# Altium schematic sheet Capteurs-projet-P1.SchDoc(Capteurs-projet-P1)
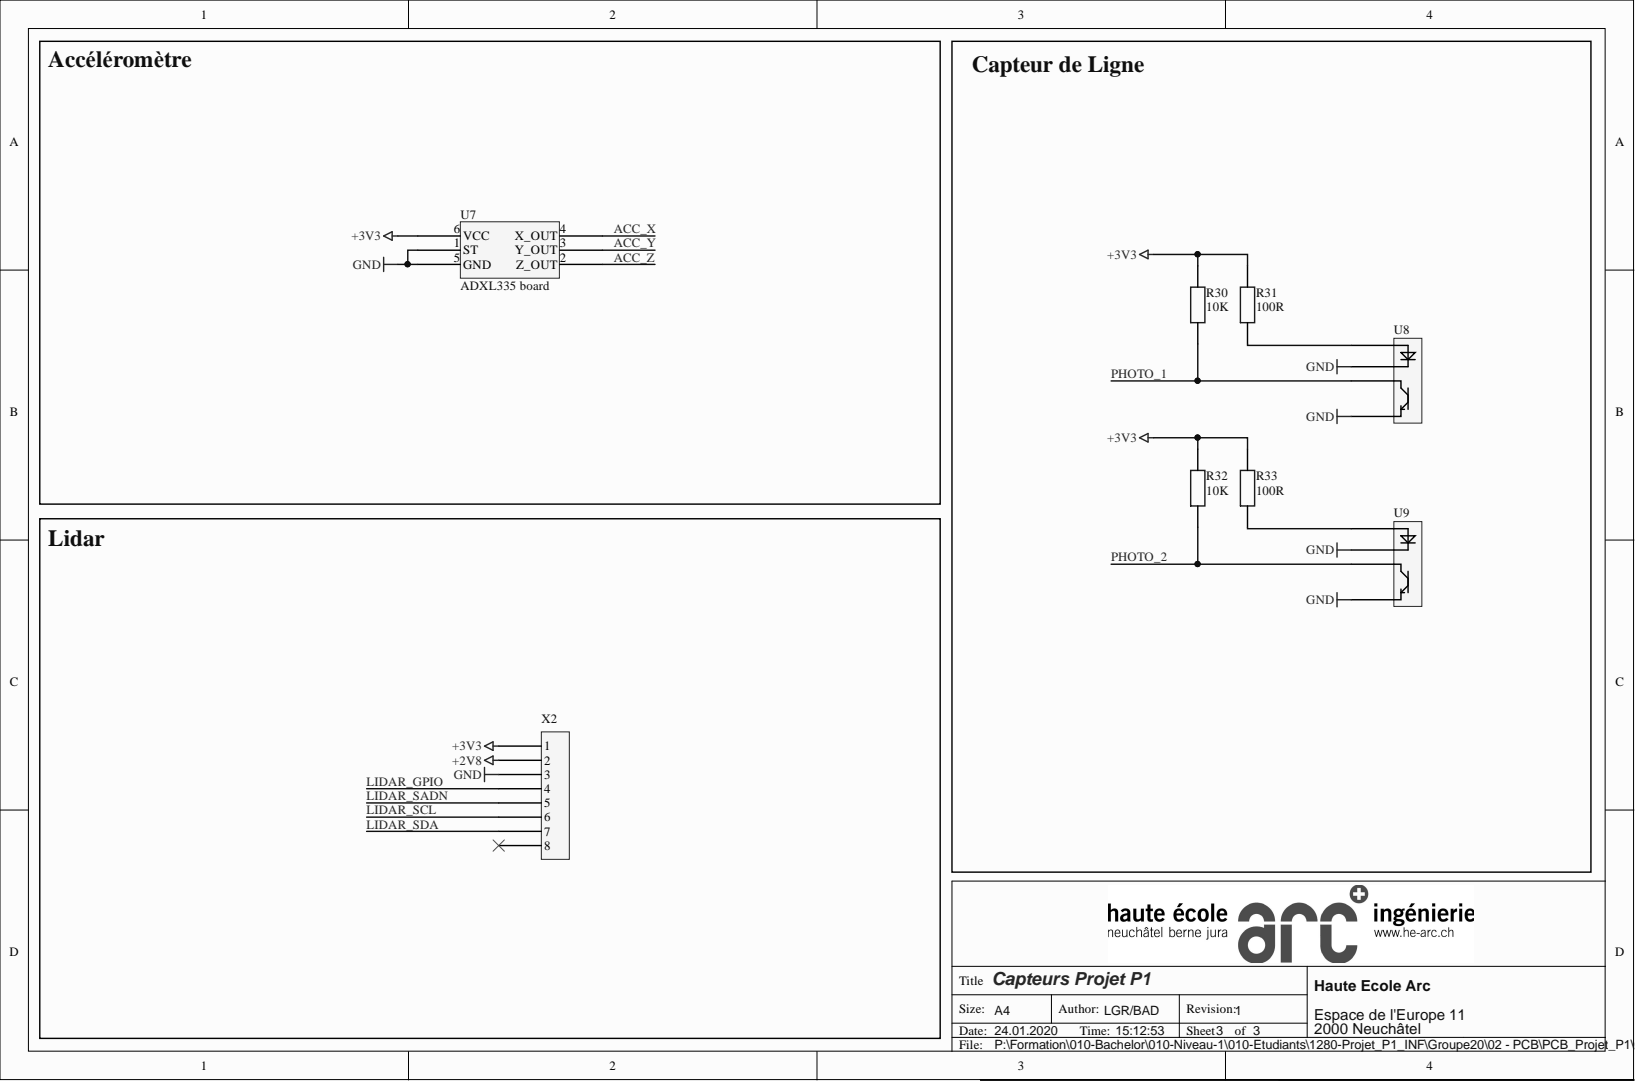
[diagram p0: Capteurs-projet-P1.SchDoc]

## Parts
R30  10K  [080501]
R31  100R  [080501]
R32  10K  [080501]
R33  100R  [080501]
U7  ADXL335 board  [SEN-09269]
U8  ITR20001/T  [ITR20001/T]  (value not drawn)
U9  ITR20001/T  [ITR20001/T]  (value not drawn)
X2  MJ1-2503A  [61200821721]  (value not drawn)

## Nets
+2V8: X2.2
+3V3: R30.2 R31.2 R32.2 R33.2 U7.6(VCC) X2.1
GND: U7.1(ST) U7.5(GND) U8.2 U8.3 U9.2 U9.3 X2.3
ACC_X: U7.4(X_OUT)
ACC_Y: U7.3(Y_OUT)
ACC_Z: U7.2(Z_OUT)
LIDAR_GPIO: X2.4
LIDAR_SADN: X2.5
LIDAR_SCL: X2.6
LIDAR_SDA: X2.7
PHOTO_1: R30.1 U8.4
PHOTO_2: R32.1 U9.4
NetR31_1: R31.1 U8.1
NetR33_1: R33.1 U9.1

Not connected: X2 8

## Library data of the parts
R30 R32 = Res-10K-0805: Résistance 10 K ohm 0805 0.125W; Ref. Fournisseur: 311-10.0KCRCT-ND; Ref. Fabricant: RC0805FR-0710KL; Prix 1 unit (CHF): 0.10; Fournisseur: Digikey; Fabricant: Yageo; Auteur: BAD; Library Name: Schlib-P1-G20.SchLib; ComponentLink1Description: datasheet; Component Kind: Standard; ComponentLink1URL: P:\Formation\010-Bachelor\010-Niveau-1\010-Etudiants\1280-Projet_P1_INF\Groupe20\01 - Documentation\01 - Composants electronique\11 - Composants passif\01 - Résistances\res-100K-0805.pdf
R31 R33 = Res-100R-0805: Résistance 100 R 0805; Ref. Fournisseur: RNCP0805FTD100RCT-ND; Ref. Fabricant: RNCP0805FTD100R; Prix 1 unit (CHF): 0.10; Fournisseur: Digikey; Fabricant: Stackpole Electronics Inc; Auteur: BAD; Library Name: Schlib-P1-G20.SchLib; ComponentLink1Description: datasheet; Component Kind: Standard; ComponentLink1URL: P:\Formation\010-Bachelor\010-Niveau-1\010-Etudiants\1280-Projet_P1_INF\Groupe20\01 - Documentation\01 - Composants electronique\11 - Composants passif\01 - Résistances\res-150-0805.pdf
U7 = ADXL335: Accéléromètre; Ref. Fournisseur: 1568-1044-ND; Prix 1 unit (CHF): 14.80; Fournisseur: Digikey; Fabricant: SparkFun; Auteur: BAD; Ref. Fabricant: SEN-09269; Library Name: Schlib-P1-G20.SchLib; ComponentLink1Description: datasheet; Component Kind: Standard; ComponentLink1URL: P:\Formation\010-Bachelor\010-Niveau-1\010-Etudiants\1280-Projet_P1_INF\Groupe20\01 - Documentation\01 - Composants electronique\02 - Accelerometre\ADXL335.pdf
U8 U9 = ITR20001/T: Capteur de ligne; Prix 1 unit (CHF): 0.83; Fournisseur: Distrelec; Fabricant: Everlight; Auteur: BAD; Ref. Fournisseur: 110-44-350; Ref. Fabricant: ITR20001/T; Library Name: Schlib-P1-G20.SchLib; ComponentLink1Description: datasheet; Component Kind: Standard; ComponentLink1URL: P:\Formation\010-Bachelor\010-Niveau-1\010-Etudiants\1280-Projet_P1_INF\Groupe20\01 - Documentation\01 - Composants electronique\04 - Capteur de position\ITR20001-T_eng_tds-2.pdf
X2 = 61200821721: Connecteur  Contre connecteur :  Digikey : 732-5444-ND; Ref. Fournisseur: 732-5405-ND; Prix 1 unit (CHF): 1.08; Fabricant: Wurth Electronics Inc.; Auteur: BAD; Ref. Fabricant: 61200821721; Library Name: Schlib-P1-G20.SchLib; Fournisseur: Digikey; ComponentLink1Description: datasheet; Component Kind: Standard; ComponentLink1URL: P:\Formation\010-Bachelor\010-Niveau-1\010-Etudiants\1280-Projet_P1_INF\Groupe20\01 - Documentation\01 - Composants electronique\10 - Connecteurs\conn-lidar\6120xx235221.pdf
